# Altium schematic sheet SPI.SchDoc(SPI)
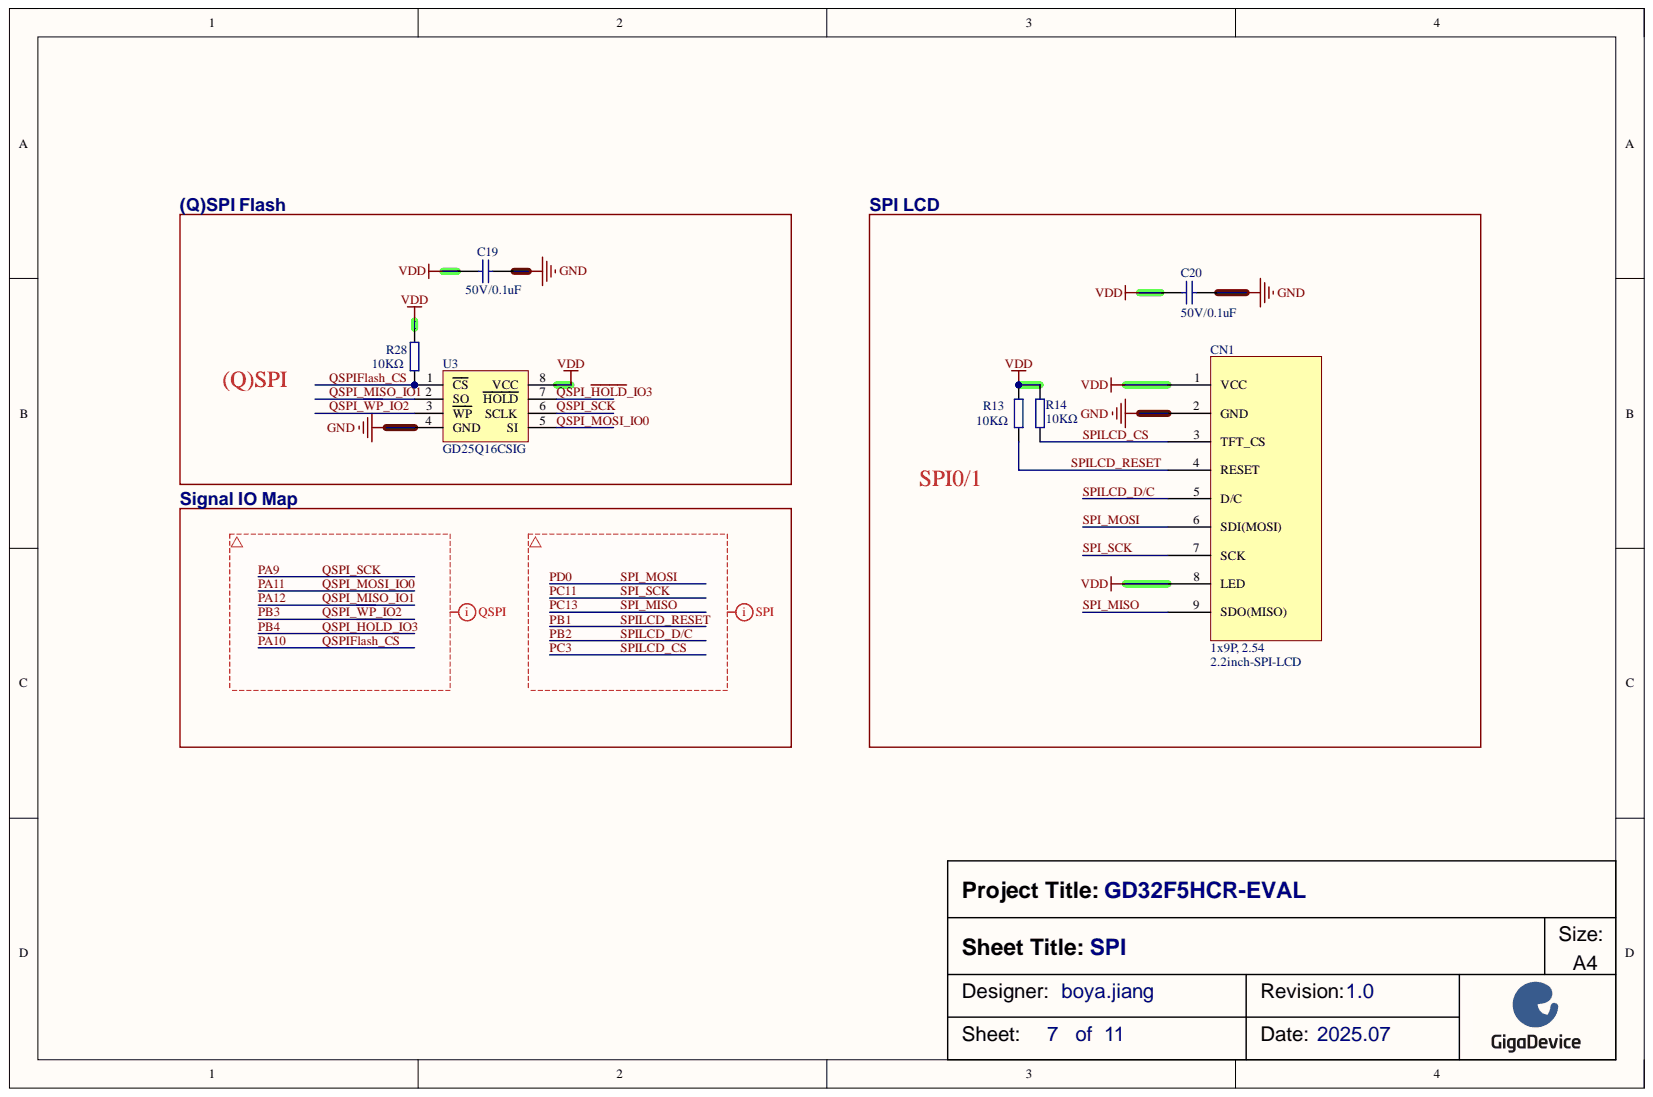
[diagram p0: SPI.SchDoc]

## Parts
C19  50V/0.1uF  [0603-C]
C20  50V/0.1uF  [0603-C]
CN1  1x9P, 2.54  Parameter 1: 2.2inch-SPI-LCD  [SIP-9, female]
R13  10KΩ  [0603-R]
R14  10KΩ  [0603-R]
R28  10KΩ  [0603-R]
U3  GD25Q16CSIG  [SOP-8(GD25Q16B)]

## Nets
GND: C19.2 C20.1 CN1.2(GND) U3.4(GND)
VDD: C19.1 C20.2 CN1.1(VCC) CN1.8(LED) R13.2 R14.2 R28.2 U3.8(VCC)
PA9: U3.6(SCLK)
PA10: R28.1 U3.1(CS)
PA11: U3.5(SI)
PA12: U3.2(SO)
PB1: CN1.4(RESET) R13.1
PB2: CN1.5(D/C)
PB3: U3.3(WP)
PB4: U3.7(HOLD)
PC3: CN1.3(TFT_CS) R14.1
PC11: CN1.7(SCK)
PC13: CN1.9(SDO(MISO))
PD0: CN1.6(SDI(MOSI))

## Library data of the parts
C19 C20 = 50V/0.1uF: 表贴电容; Component Kind: Standard; Library Name: KLTC-电容.SchLib
CN1 = 2.2inch_SPI_LCD_Interface: 单排排母; Component Kind: Standard; Library Name: boyajiang.SchLib
R13 R14 R28 = 10KΩ: 表贴电阻; Component Kind: Standard; Library Name: KLTC-电阻.SchLib
U3 = GD25Q40: 表贴SPI Flash; Note: GD供料; Component Kind: Standard; Library Name: xufei.SchLib
